# Altium schematic sheet 限位.SchDoc(限位)
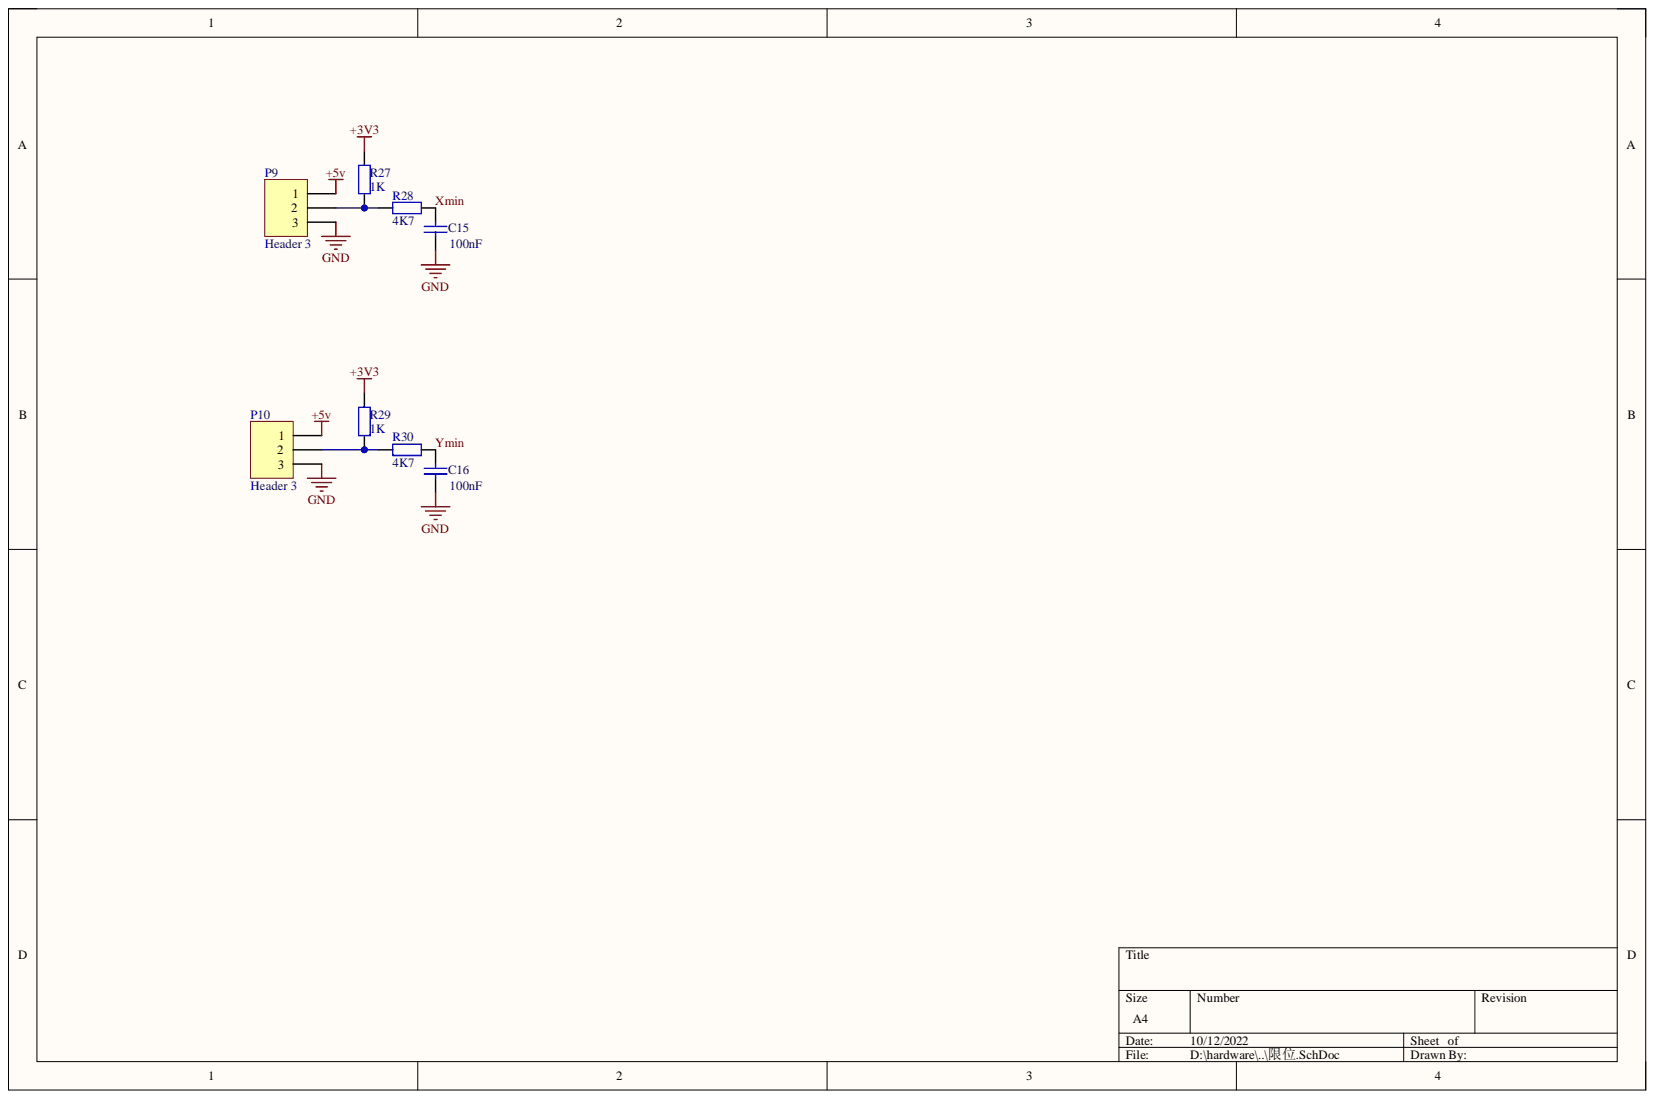
[diagram p0: 限位.SchDoc]

## Parts
C15  100nF  [0805c]
C16  100nF  [0805c]
P9  Header 3  [KF2EDG-2.54-3-H]
P10  Header 3  [KF2EDG-2.54-3-H]
R27  1K  [0805r]
R28  4K7  [0805r]
R29  1K  [0805r]
R30  4K7  [0805r]

## Nets
+3V3: R27.1 R29.1
+5v: P9.1 P10.1
GND: C15.1 C16.1 P9.3 P10.3
Xmin: C15.2 R28.2
Ymin: C16.2 R30.2
NetP9_2: P9.2 R27.2 R28.1
NetP10_2: P10.2 R29.2 R30.1

## Library data of the parts
C15 C16 = Cap: 无极性定值电容; Component Kind: Standard; LatestRevisionDate: 17-Jul-2002; LatestRevisionNote: Re-released for DXP Platform.; Library Name: 常用.SchLib; PackageDescription: Radial Cap, Thru-Hole; 2 Leads; 0.3 in Pin Spacing; PackageReference: RAD-0.3; Published: 8-Jun-2000; Signal Integrity: Cap; Simulation: CAP; Publisher: Altium Limited
P9 P10 = Header 3: Header, 3-Pin; Component Kind: Standard; LatestRevisionDate: 17-Jul-2002; LatestRevisionNote: Re-released for DXP Platform.; Signal Integrity: Connector; Library Name: 接插头.SchLib; Publisher: Altium Limited
R27-R30 = Res2: 定值电阻; Component Kind: Standard; LatestRevisionDate: 17-Jul-2002; LatestRevisionNote: Re-released for DXP Platform.; Library Name: 常用.SchLib; PackageDescription: Axial Device, Thru-Hole; 2 Leads; 0.4 in Pin Spacing; PackageReference: AXIAL-0.4; Published: 8-Jun-2000; Signal Integrity: Res; Simulation: RESISTOR; Publisher: Altium Limited
